# Altium schematic sheet nanoESP32S3.SchDoc
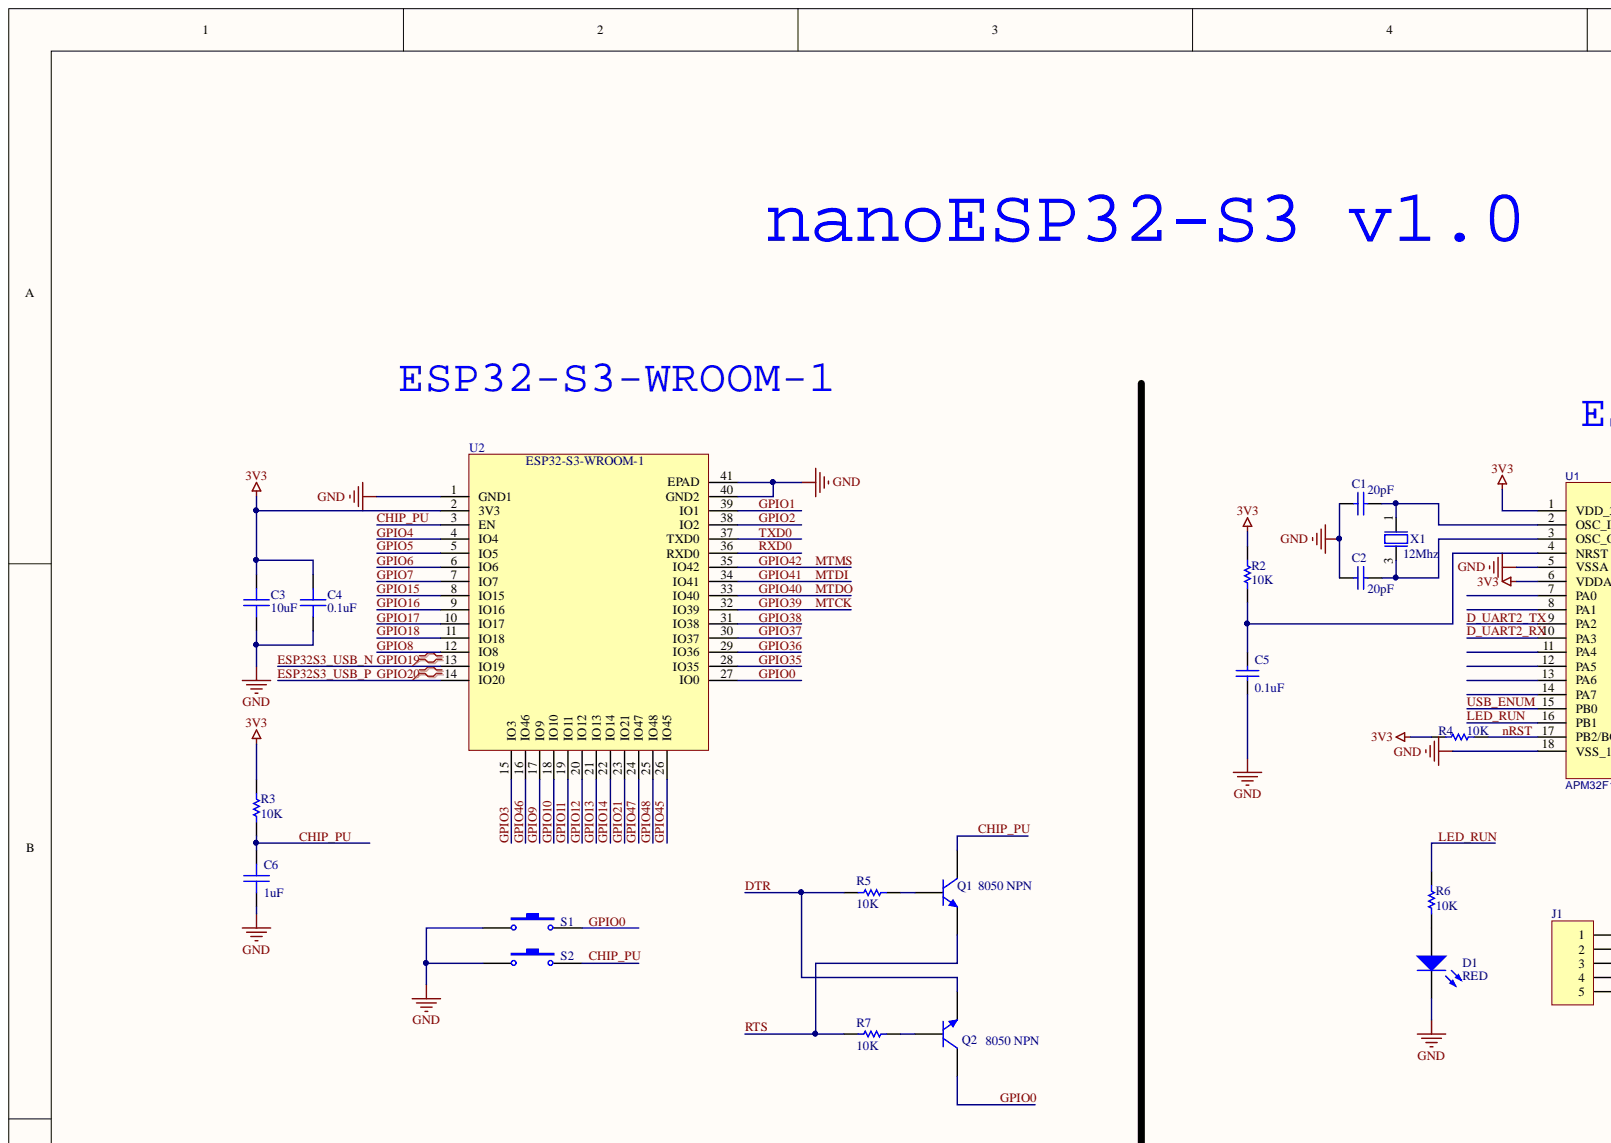
[diagram p0: nanoESP32S3.SchDoc, top left]
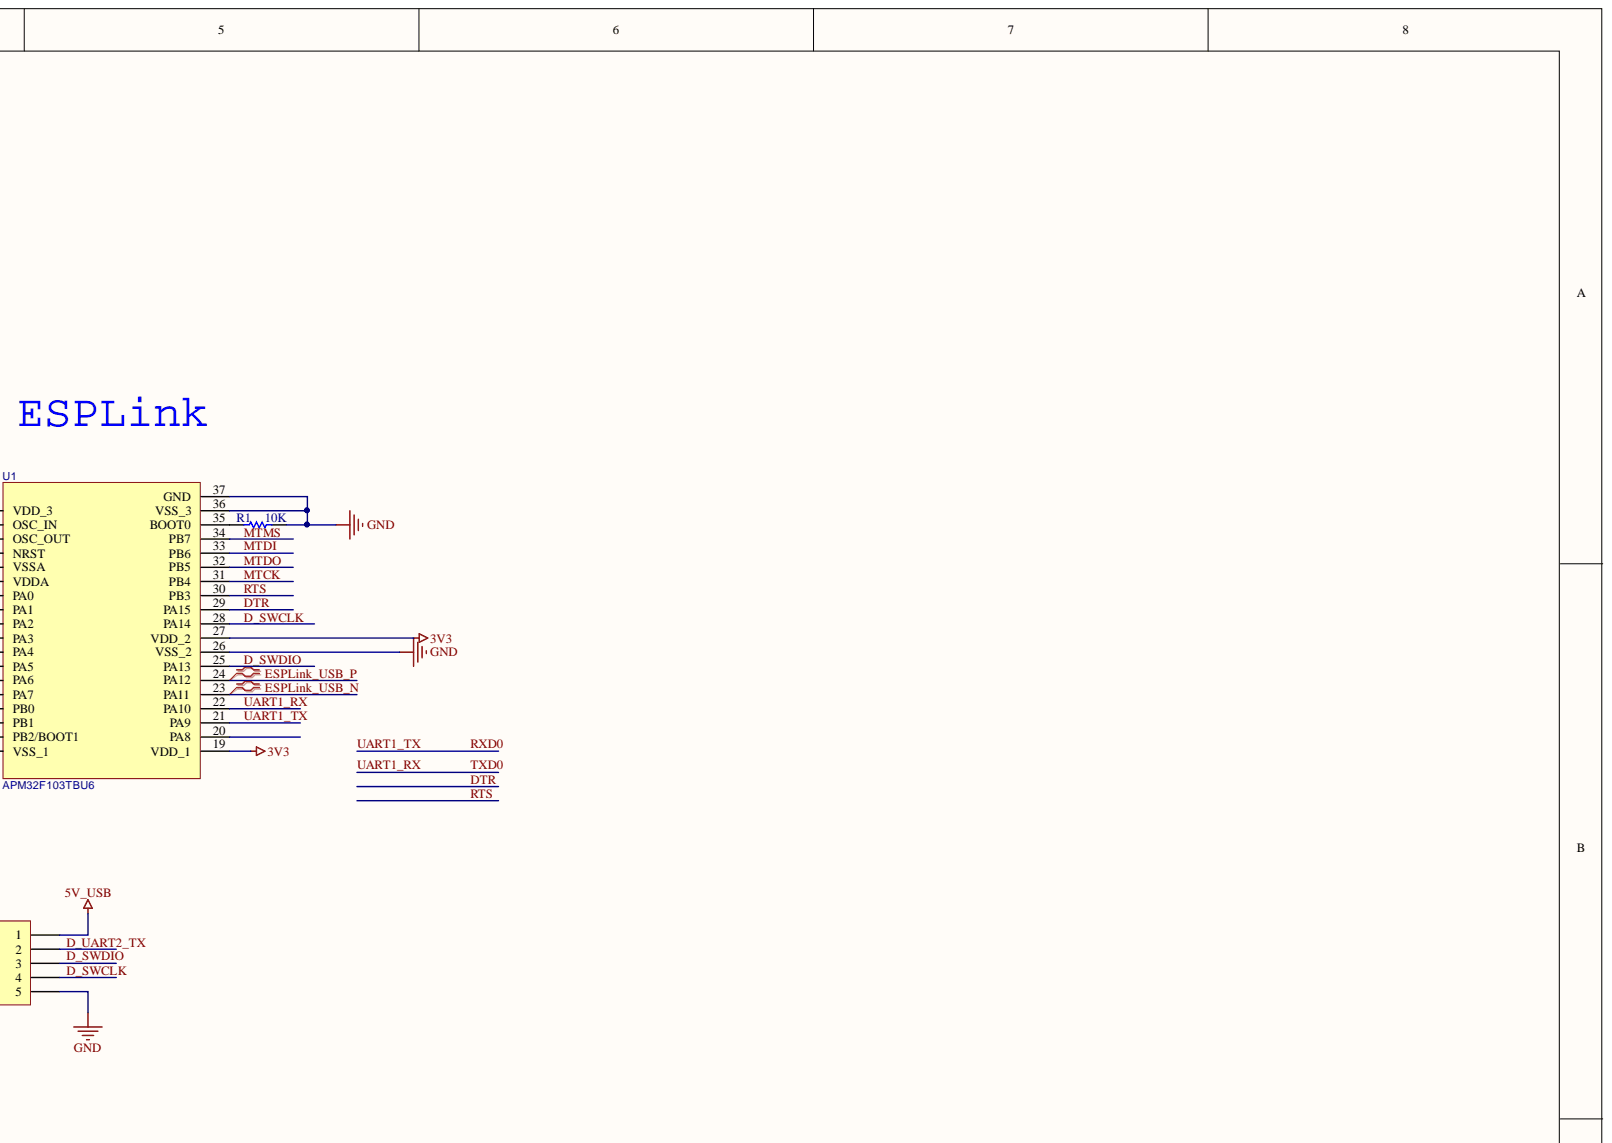
[diagram p1: nanoESP32S3.SchDoc, top right]
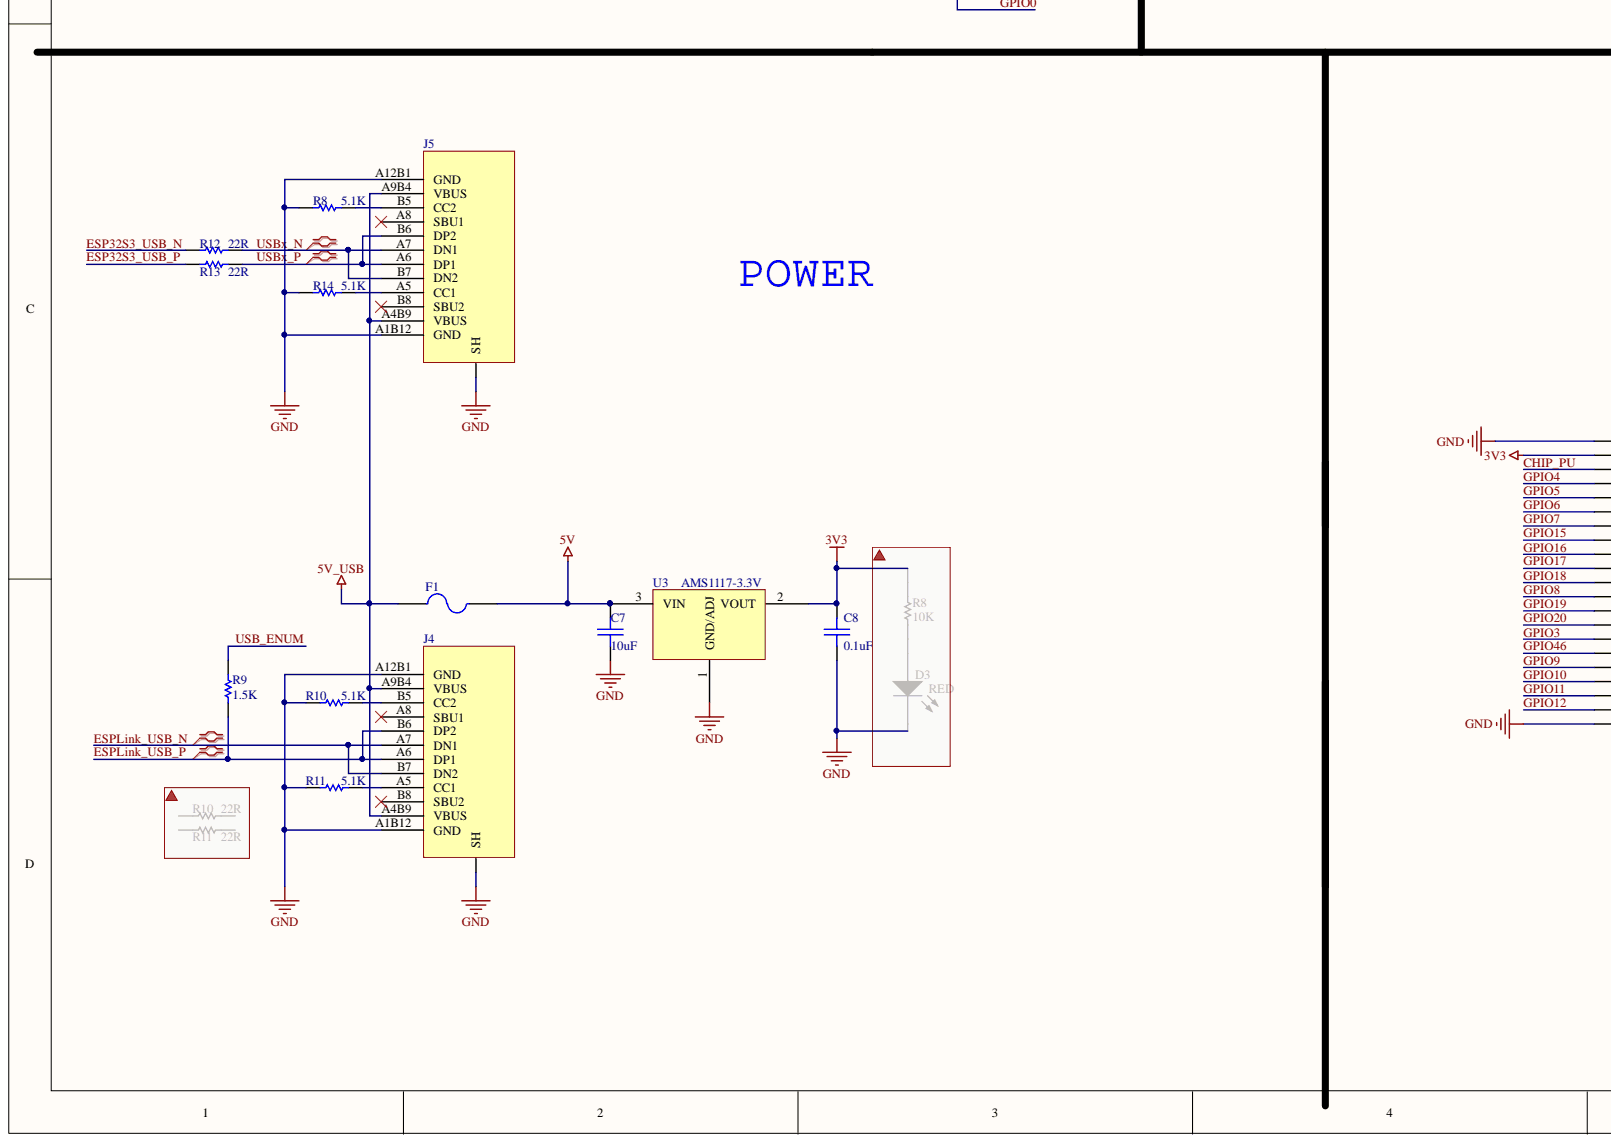
[diagram p2: nanoESP32S3.SchDoc, bottom left]
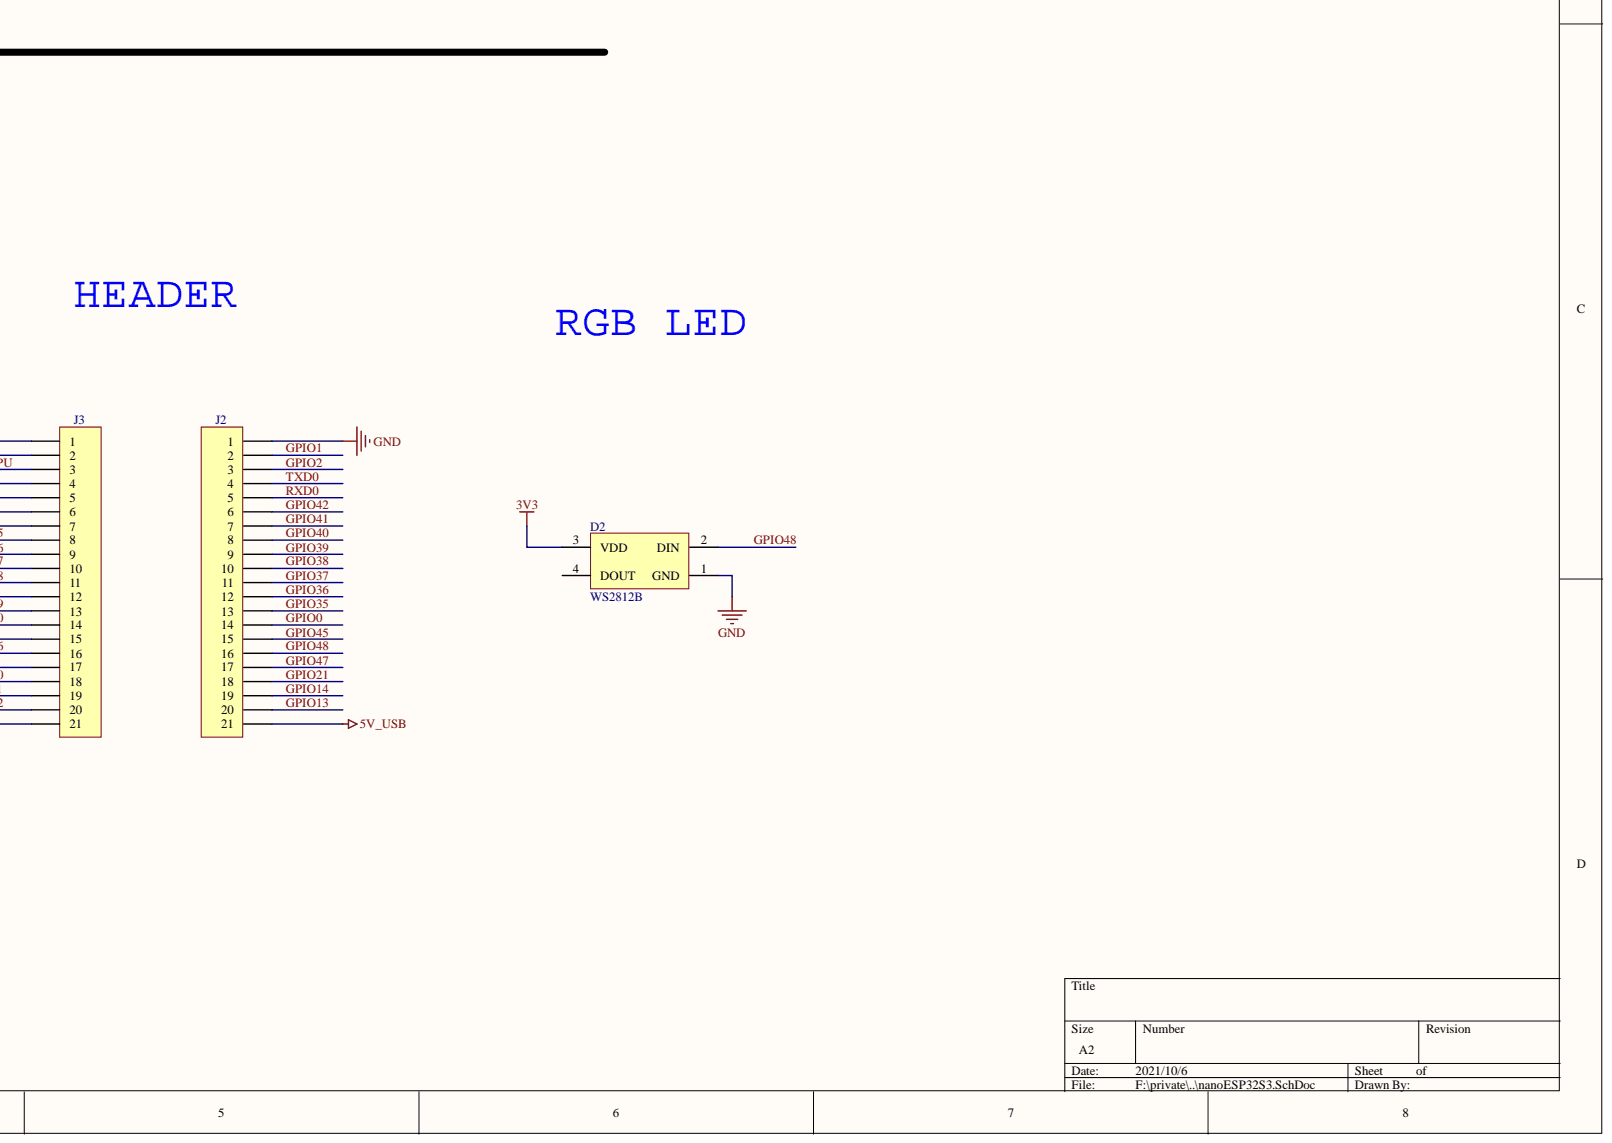
[diagram p3: nanoESP32S3.SchDoc, bottom right]

## Parts
C1  value 20pF  [C 0402_M_new]  (hidden comment: C0603)
C2  value 20pF  [C 0402_M_new]  (hidden comment: C0603)
C3  10uF  [0603_C]
C4  0.1uF  [C 0402_M_new]
C5  value 0.1uF  [C 0402_M_new]  (hidden comment: C0603)
C6  1uF  [C 0402_M_new]
C7  10uF  [0603_C]
C8  0.1uF  [0603_C]
D1  RED  [0603_LED]
D2  WS2812B  [LED 3528-4Pin]
F1  Fuse 2  [FU 0805]  (value not drawn)
J1  (no value)  [HEADER_1.27_SMT_5x1]
J2  Header 21  [HEADER_21x1]  (value not drawn)
J3  Header 21  [HEADER_21x1]  (value not drawn)
J4  Type_C_16P  [Type_C_16P]  (value not drawn)
J5  Type_C_16P  [Type_C_16P]  (value not drawn)
Q1  value 8050 NPN  [SOT23-8050]  (hidden comment: MMBT8050 NPN)
Q2  value 8050 NPN  [SOT23-8050]  (hidden comment: MMBT8050 NPN)
R1  value 10K  [R 0402_M_new]  (hidden comment: R0603)
R2  value 10K  [R 0402_M_new]  (hidden comment: R0603)
R3  value 10K  [R 0402_M_new]  (hidden comment: R0603)
R4  value 10K  [R 0402_M_new]  (hidden comment: R0603)
R5  value 10K  [R 0402_M_new]  (hidden comment: R0603)
R6  value 10K  [R 0402_M_new]  (hidden comment: R0603)
R7  value 10K  [R 0402_M_new]  (hidden comment: R0603)
R8  value 5.1K  [R 0402_M_new]  (hidden comment: R0603)
R9  value 1.5K  [R 0402_M_new]  (hidden comment: R0603)
R10  value 5.1K  [R 0402_M_new]  (hidden comment: R0603)
R11  value 5.1K  [R 0402_M_new]  (hidden comment: R0603)
R12  value 22R  [R 0402_M_new]  (hidden comment: R0603)
R13  value 22R  [R 0402_M_new]  (hidden comment: R0603)
R14  value 5.1K  [R 0402_M_new]  (hidden comment: R0603)
S1  SW-PB  [SMT_SW_PTS_820]  (value not drawn)
S2  SW-PB  [SMT_SW_PTS_820]  (value not drawn)
U1  APM32F103TBU6  [*QFN50P600X600X100-37N]
U2  ESP32-S3-WROOM-1  [ESP32-S3-WROOM-1]
U3  AMS1117-3.3V  [SOT-89]
X1  12Mhz  [BT-XTAL_3225]

## Nets
3V3: C3.1 C4.1 C8.1 D2.3(VDD) J3.2 R2.1 R3.2 R4.1 U1.1(VDD_3) U1.6(VDDA) U1.19(VDD_1) U1.27(VDD_2) U2.2(3V3) U3.2
5V: C7.1 F1.2 U3.3
5V_USB: F1.1 J1.1 J2.21 J4.A4B9(VBUS) J4.A9B4(VBUS) J5.A4B9(VBUS) J5.A9B4(VBUS)
GND: C1.2 C2.2 C3.2 C4.2 C5.1 C6.2 C7.2 C8.2 D1.2 D2.1(GND) J1.5 J2.1 J3.1 J3.21 J4.0(SH) J4.A1B12(GND) J4.A12B1(GND) J5.0(SH) J5.A1B12(GND) J5.A12B1(GND) R1.2 R8.1 R10.1 R11.1 R14.1 S1.1 S2.1 U1.5(VSSA) U1.18(VSS_1) U1.26(VSS_2) U1.36(VSS_3) U1.37(GND) U2.1(GND1) U2.40(GND2) U2.41(EPAD) U3.1 X1.2 X1.4
CHIP_PU: C6.1 J3.3 Q1.1 R3.1 S2.2 U2.3(EN)
D_SWCLK: J1.4 U1.28(PA14)
D_SWDIO: J1.3 U1.25(PA13)
D_UART2_RX: U1.10(PA3)
D_UART2_TX: J1.2 U1.9(PA2)
DTR: Q2.3 R5.2 U1.29(PA15)
ESP32S3_USB_N: J3.13 R12.1 U2.13(IO19)
ESP32S3_USB_P: J3.14 R13.1 U2.14(IO20)
ESPLink_USB_N: J4.A7(DN1) J4.B7(DN2) U1.23(PA11)
ESPLink_USB_P: J4.A6(DP1) J4.B6(DP2) R9.2 U1.24(PA12)
GPIO0: J2.14 Q2.1 S1.2 U2.27(IO0)
GPIO1: J2.2 U2.39(IO1)
GPIO2: J2.3 U2.38(IO2)
GPIO3: J3.15 U2.15(IO3)
GPIO4: J3.4 U2.4(IO4)
GPIO5: J3.5 U2.5(IO5)
GPIO6: J3.6 U2.6(IO6)
GPIO7: J3.7 U2.7(IO7)
GPIO8: J3.12 U2.12(IO8)
GPIO9: J3.17 U2.17(IO9)
GPIO10: J3.18 U2.18(IO10)
GPIO11: J3.19 U2.19(IO11)
GPIO12: J3.20 U2.20(IO12)
GPIO13: J2.20 U2.21(IO13)
GPIO14: J2.19 U2.22(IO14)
GPIO15: J3.8 U2.8(IO15)
GPIO16: J3.9 U2.9(IO16)
GPIO17: J3.10 U2.10(IO17)
GPIO18: J3.11 U2.11(IO18)
GPIO21: J2.18 U2.23(IO21)
GPIO35: J2.13 U2.28(IO35)
GPIO36: J2.12 U2.29(IO36)
GPIO37: J2.11 U2.30(IO37)
GPIO38: J2.10 U2.31(IO38)
GPIO39: J2.9 U1.31(PB4) U2.32(IO39)
GPIO40: J2.8 U1.32(PB5) U2.33(IO40)
GPIO41: J2.7 U1.33(PB6) U2.34(IO41)
GPIO42: J2.6 U1.34(PB7) U2.35(IO42)
GPIO45: J2.15 U2.26(IO45)
GPIO46: J3.16 U2.16(IO46)
GPIO47: J2.17 U2.24(IO47)
GPIO48: D2.2(DIN) J2.16 U2.25(IO48)
LED_RUN: R6.2 U1.16(PB1)
nRST: R4.2 U1.17(PB2/BOOT1)
RTS: Q1.3 R7.2 U1.30(PB3)
RXD0: J2.5 U1.21(PA9) U2.36(RXD0)
TXD0: J2.4 U1.22(PA10) U2.37(TXD0)
USB_ENUM: R9.1 U1.15(PB0)
USBx_N: J5.A7(DN1) J5.B7(DN2) R12.2
USBx_P: J5.A6(DP1) J5.B6(DP2) R13.2
NetC1_1: C1.1 U1.2(OSC_IN) X1.1
NetC2_1: C2.1 U1.3(OSC_OUT) X1.3
NetC5_2: C5.2 R2.2 U1.4(NRST)
NetD1_1: D1.1 R6.1
NetJ4_A5: J4.A5(CC1) R11.2
NetJ4_B5: J4.B5(CC2) R10.2
NetJ5_A5: J5.A5(CC1) R14.2
NetJ5_B5: J5.B5(CC2) R8.2
NetQ1_2: Q1.2 R5.1
NetQ2_2: Q2.2 R7.1
NetR1_1: R1.1 U1.35(BOOT0)

Not connected: D2 4(DOUT); J4 A8(SBU1) B8(SBU2); J5 A8(SBU1) B8(SBU2); U1 7(PA0) 8(PA1) 11(PA4) 12(PA5) 13(PA6) 14(PA7) 20(PA8)

## Library data of the parts
C1 C2 C5 = C0603: Capacitor; Component Kind: Standard; LatestRevisionDate: 17-Jul-2002; LatestRevisionNote: Re-released for DXP Platform.; Library Name: MYLIB.IntLib; PackageDescription: Radial Cap, Thru-Hole; 2 Leads; 0.3 in Pin Spacing; PackageReference: RAD-0.3; Published: 8-Jun-2000; Signal Integrity: Cap; Simulation: CAP; Publisher: Altium Limited
C3 C6 C7 = SMT_C_0805: Surface mount capacitor 0805; Component Kind: Standard; Library Name: Vowstar_Basic_Device.IntLib
C4 C8 = SMT_C_0402: Surface mount capacitor 0402; Component Kind: Standard; Library Name: Vowstar_Basic_Device.IntLib
D1 = D0603: Typical RED, GREEN, YELLOW, AMBER GaAs LED; Component Kind: Standard; LatestRevisionDate: 17-Jul-2002; LatestRevisionNote: Re-released for DXP Platform.; Library Name: MYLIB.IntLib; PackageDescription: SM LED; 2 Flat Leads; PackageReference: 3.2X1.6X1.1; PackageVersion: Feb-2002; Published: 8-Jun-2000; Simulation: LED2; Publisher: Altium Limited
F1 = Fuse 2: Fuse; Component Kind: Standard; LatestRevisionDate: 17-Jul-2002; LatestRevisionNote: Re-released for DXP Platform.; Library Name: Miscellaneous Devices.IntLib; PackageDescription: Thru-Hole; 2 Leads; PackageReference: PIN-W2/E2.8; Published: 8-Jun-2000; Simulation: FUSE; Publisher: Altium Limited
J1 = Header_5: Header, 4-Pin; Component Kind: Standard; LatestRevisionDate: 17-Jul-2002; LatestRevisionNote: Re-released for DXP Platform.; Signal Integrity: Connector; Library Name: ICESugar-Pro.SCHLIB; Publisher: Altium Limited
Q1 Q2 = NPN: NPN Bipolar Transistor; Code_JEDEC: TO-226-AA; Component Kind: Standard; LatestRevisionDate: 17-Jul-2002; LatestRevisionNote: Re-released for DXP Platform.; Library Name: Miscellaneous Devices.IntLib; PackageDescription: TO, Flat Index; 3 In-Line, Axial Leads; Body Dia. 4.8mm; Leads 0.55 x 0.51 mm (max); PackageReference: TO-226-AA; Published: 8-Jun-2000; Publisher: Altium Limited
R1-R14 = R0603: Resistor; Component Kind: Standard; LatestRevisionDate: 17-Jul-2002; LatestRevisionNote: Re-released for DXP Platform.; Library Name: MYLIB.IntLib; PackageDescription: Axial Device, Thru-Hole; 2 Leads; 0.3 in Pin Spacing; PackageReference: AXIAL-0.3; Published: 8-Jun-2000; Signal Integrity: Res; Simulation: RESISTOR; Publisher: Altium Limited
S1 S2 = SW-PB: Switch; Component Kind: Standard; LatestRevisionDate: 17-Jul-2002; LatestRevisionNote: Re-released for DXP Platform.; Library Name: Miscellaneous Devices.IntLib; PackageDescription: Switch; 2 Leads; PackageReference: SPST-2; Published: 24-Mar-1999; Publisher: Altium Limited
U1 = STM32F103T8U6: BOM_Manufacturer: STMicroelectronics; BOM_Manufacturer Part: STM32F103T8U6; BOM_Supplier: LCSC; BOM_Supplier Part: C19671; Component Kind: Standard; image: //image.lceda.cn/szlcsc/C19671.jpg; Library Name: teensy.SCHLIB; spicePre: U
U3 = NCP1117: NCP1117, NCV1117. 1.0 A Low-Dropout Positive  Fixed and Adjustable  Voltage Regulators; Component Kind: Standard; Library Name: Vowstar_IC_General.IntLib
X1 = XTAL SMD 12MHz (3225): XTAL SMD 3225, 8MHz, 18pF, ±30ppm; 2nd source part number: 184-2056; Manufacturer: TXC Corporation; Manufacturer Part Number: 7M-12.000MAAJ-T; Artikkelnr Noca: 22019166; Artikkelnr Simpro: N.A.; Database Table Name: Crystal_Osc$; Note: XTAL SMD 12.000MHz; 2nd source Supplier: Farnell; Component Kind: Standard; Datasheet: http://www.txccrystal.com/images/pdf/7m.pdf; Footprint Doc: BT-XTAL_3225; LatestRevisionDate: 2010-08-31; Library Name: Nordic Discrete DB.DbLib; Part Number: XTAL SMD 12MHz (3225); Pin Count: 4; Published: 2010-08-31; Supplier: Digi-Key; Supplier part number: 887-1121-1-ND; Value: 12Mhz; Publisher: Nordic Semiconductor
D2 = WS2812B: Component Kind: Standard; Library Name: nanoESP32S2.SCHLIB
J2 J3 = Header 21: Component Kind: Standard; Library Name: nanoESP32S2.SCHLIB
J4 J5 = Type_C_16P: Component Kind: Standard; Library Name: ICESugar.SCHLIB
U2 = ESP32-S3-WROOM-1: Component Kind: Standard
